# Altium schematic sheet RP2350+.SchDoc
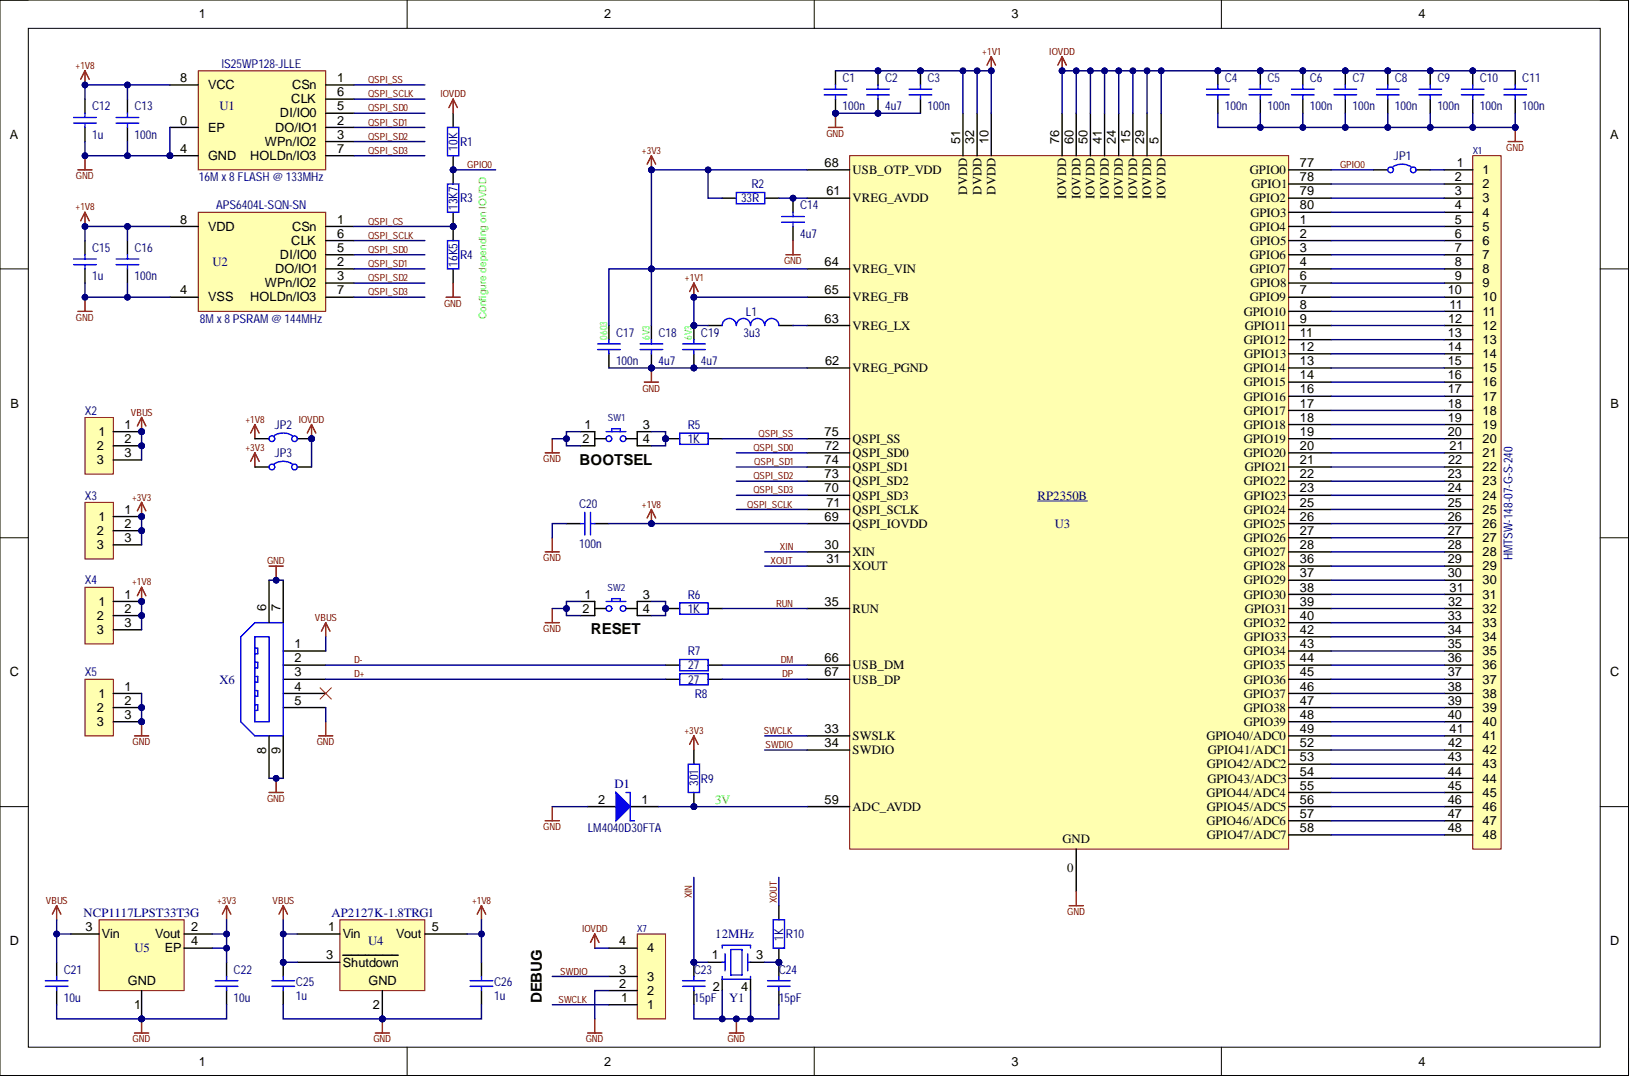
[diagram p0: RP2350+.SchDoc]

## Parts
C1  value 100n  [CAPC0402]  (hidden comment: C0402C104J8RACTU)
C2  value 4u7  [CAPC0402]  (hidden comment: CL05A475KP5NRNC)
C3  value 100n  [CAPC0402]  (hidden comment: C0402C104J8RACTU)
C4  value 100n  [CAPC0402]  (hidden comment: C0402C104J8RACTU)
C5  value 100n  [CAPC0402]  (hidden comment: C0402C104J8RACTU)
C6  value 100n  [CAPC0402]  (hidden comment: C0402C104J8RACTU)
C7  value 100n  [CAPC0402]  (hidden comment: C0402C104J8RACTU)
C8  value 100n  [CAPC0402]  (hidden comment: C0402C104J8RACTU)
C9  value 100n  [CAPC0402]  (hidden comment: C0402C104J8RACTU)
C10  value 100n  [CAPC0402]  (hidden comment: C0402C104J8RACTU)
C11  value 100n  [CAPC0402]  (hidden comment: C0402C104J8RACTU)
C12  value 1u  [CAPC0402]  (hidden comment: CL05A105KP5NNNC)
C13  value 100n  [CAPC0402]  (hidden comment: C0402C104J8RACTU)
C14  value 4u7  [CAPC0402]  (hidden comment: CL05A475KP5NRNC)
C15  value 1u  [CAPC0402]  (hidden comment: CL05A105KP5NNNC)
C16  value 100n  [CAPC0402]  (hidden comment: C0402C104J8RACTU)
C17  0603  value 100n  [CAPC0603]
C18  6V3  value 4u7  [CAPC0603]
C19  6V3  value 4u7  [CAPC0603]
C20  value 100n  [CAPC0402]  (hidden comment: C0402C104J8RACTU)
C21  value 10u  [CAPC0402]  (hidden comment: 6V3)
C22  value 10u  [CAPC0402]  (hidden comment: 6V3)
C23  value 15pF  [CAPC0402]  (hidden comment: GJM1555C1H3R0BB01D)
C24  value 15pF  [CAPC0402]  (hidden comment: GJM1555C1H3R0BB01D)
C25  value 1u  [CAPC0402]  (hidden comment: CL05A105KP5NNNC)
C26  value 1u  [CAPC0402]  (hidden comment: CL05A105KP5NNNC)
D1  LM4040D30FTA  [SOT23-3]
JP1  5110 Keystone  [JumperSMD_0603]  (value not drawn)  (no BOM)
JP2  5110 Keystone  [JumperSMD_0603]  (value not drawn)  (no BOM)
JP3  5110 Keystone  [JumperSMD_0603]  (value not drawn)  (no BOM)
L1  value 3u3  [IND_0806]  (hidden comment: IND_3u3_2X1.6mm_1.4A_20%)
R1  value 10K  [RESC0402]  (hidden comment: RC0402FR-0710KL)
R2  value 33R  [RESC0402]  (hidden comment: RC0402FR-0733RL)
R3  value 13K7  [RESC0402]  (hidden comment: 13K7_0402_63mW_1%_100ppm)
R4  value 16K5  [RESC0402]  (hidden comment: 16K5_0402_63mW_1%_100ppm)
R5  value 1K  [RESC0402]  (hidden comment: RC0402FR-071KL)
R6  value 1K  [RESC0402]  (hidden comment: RC0402FR-071KL)
R7  value 27  [RESC0402]  (hidden comment: CRCW040214K3FKED)
R8  value 27  [RESC0402]  (hidden comment: CRCW040214K3FKED)
R9  value 301  [RESC0402]  (hidden comment: 301R_0402_63mW_1%_100ppm)
R10  value 1K  [RESC0402]  (hidden comment: RC0402FR-071KL)
SW1  434133025816  [434133025816]  (value not drawn)
SW2  434133025816  [434133025816]  (value not drawn)
U1  IS25WP128-JLLE  value 16M x 8 FLASH @ 133MHz  [WSON8-6x8]
U2  APS6404L-SQN-SN  value 8M x 8 PSRAM @ 144MHz  [SOP-8L (150)]
U3  RP2350B  [QFN-80]
U4  AP2127K-1.8TRG1  [SOT23-5]
U5  NCP1117LPST33T3G  [SOT-232-4]
X1  HMTSW-148-07-G-S-240  [HDR_TH_1X48_M_00]
X2  825433-3  [HDR_TH_1X3_M_00]  (value not drawn)
X3  825433-3  [HDR_TH_1X3_M_00]  (value not drawn)
X4  825433-3  [HDR_TH_1X3_M_00]  (value not drawn)
X5  825433-3  [HDR_TH_1X3_M_00]  (value not drawn)
X6  1734327-1  [1734327-1]  (value not drawn)
X7  HMTSW-106-07-G-S-240  [HDR_TH_1X3+0+1_M_00]  (value not drawn)
Y1  value 12MHz  [ABM8]  (hidden comment: ABM8-272-T3)

## Nets
+1V1: C1.2 C2.2 C3.2 C19.2 L1.1 U3.10(DVDD) U3.32(DVDD) U3.51(DVDD) U3.65(VREG_FB)
+1V8: C12.1 C13.2 C15.1 C16.2 C20.2 C26.1 JP2.1 U1.8(VCC) U2.8(VDD) U3.69(QSPI_IOVDD) U4.5(Vout) X4.1 X4.2 X4.3
+3V3: C17.2 C18.2 C22.2 JP3.1 R2.1 R9.2 U3.64(VREG_VIN) U3.68(USB_OTP_VDD) U5.2(Vout) U5.4(EP) X3.1 X3.2 X3.3
GND: C1.1 C2.1 C3.1 C4.1 C5.1 C6.1 C7.1 C8.1 C9.1 C10.1 C11.1 C12.2 C13.1 C14.1 C15.2 C16.1 C17.1 C18.1 C19.1 C20.1 C21.1 C22.1 C23.1 C24.1 C25.2 C26.2 D1.2 R4.1 SW1.1 SW1.2 SW2.1 SW2.2 U1.0(EP) U1.4(GND) U2.4(VSS) U3.0 U3.62(VREG_PGND) U4.2 U5.1 X5.1 X5.2 X5.3 X6.5 X6.6 X6.7 X6.8 X6.9 X7.2 Y1.2 Y1.4
IOVDD: C4.2 C5.2 C6.2 C7.2 C8.2 C9.2 C10.2 C11.2 JP2.2 JP3.2 R1.1 U3.5(IOVDD) U3.15(IOVDD) U3.24(IOVDD) U3.29(IOVDD) U3.41(IOVDD) U3.50(IOVDD) U3.60(IOVDD) U3.76(IOVDD) X7.4
VBUS: C21.2 C25.1 U4.1(Vin) U4.3(Shutdown) U5.3(Vin) X2.1 X2.2 X2.3 X6.1
D+: R8.1 X6.3
D-: R7.1 X6.2
DM: R7.2 U3.66(USB_DM)
DP: R8.2 U3.67(USB_DP)
GPIO0: JP1.1 R1.2 R3.2 U3.77(GPIO0)
QSPI_CS: R3.1 R4.2 U2.1(CSn)
QSPI_SCLK: U1.6(CLK) U2.6(CLK) U3.71(QSPI_SCLK)
QSPI_SD0: U1.5(DI/IO0) U2.5(DI/IO0) U3.72(QSPI_SD0)
QSPI_SD1: U1.2(DO/IO1) U2.2(DO/IO1) U3.74(QSPI_SD1)
QSPI_SD2: U1.3(WPn/IO2) U2.3(WPn/IO2) U3.73(QSPI_SD2)
QSPI_SD3: U1.7(HOLDn/IO3) U2.7(HOLDn/IO3) U3.70(QSPI_SD3)
QSPI_SS: R5.2 U1.1(CSn) U3.75(QSPI_SS)
RUN: R6.2 U3.35(RUN)
SWCLK: U3.33(SWSLK) X7.1
SWDIO: U3.34(SWDIO) X7.3
XIN: C23.2 U3.30(XIN) Y1.1
XOUT: R10.2 U3.31(XOUT)
NetC14_2: C14.2 R2.2 U3.61(VREG_AVDD)
NetC24_2: C24.2 R10.1 Y1.3
NetD1_1: D1.1 R9.1 U3.59(ADC_AVDD)
NetJP1_2: JP1.2 X1.1
NetL1_2: L1.2 U3.63(VREG_LX)
NetR5_1: R5.1 SW1.3 SW1.4
NetR6_1: R6.1 SW2.3 SW2.4
NetU3_1: U3.1(GPIO4) X1.5
NetU3_2: U3.2(GPIO5) X1.6
NetU3_3: U3.3(GPIO6) X1.7
NetU3_4: U3.4(GPIO7) X1.8
NetU3_6: U3.6(GPIO8) X1.9
NetU3_7: U3.7(GPIO9) X1.10
NetU3_8: U3.8(GPIO10) X1.11
NetU3_9: U3.9(GPIO11) X1.12
NetU3_11: U3.11(GPIO12) X1.13
NetU3_12: U3.12(GPIO13) X1.14
NetU3_13: U3.13(GPIO14) X1.15
NetU3_14: U3.14(GPIO15) X1.16
NetU3_16: U3.16(GPIO16) X1.17
NetU3_17: U3.17(GPIO17) X1.18
NetU3_18: U3.18(GPIO18) X1.19
NetU3_19: U3.19(GPIO19) X1.20
NetU3_20: U3.20(GPIO20) X1.21
NetU3_21: U3.21(GPIO21) X1.22
NetU3_22: U3.22(GPIO22) X1.23
NetU3_23: U3.23(GPIO23) X1.24
NetU3_25: U3.25(GPIO24) X1.25
NetU3_26: U3.26(GPIO25) X1.26
NetU3_27: U3.27(GPIO26) X1.27
NetU3_28: U3.28(GPIO27) X1.28
NetU3_36: U3.36(GPIO28) X1.29
NetU3_37: U3.37(GPIO29) X1.30
NetU3_38: U3.38(GPIO30) X1.31
NetU3_39: U3.39(GPIO31) X1.32
NetU3_40: U3.40(GPIO32) X1.33
NetU3_42: U3.42(GPIO33) X1.34
NetU3_43: U3.43(GPIO34) X1.35
NetU3_44: U3.44(GPIO35) X1.36
NetU3_45: U3.45(GPIO36) X1.37
NetU3_46: U3.46(GPIO37) X1.38
NetU3_47: U3.47(GPIO38) X1.39
NetU3_48: U3.48(GPIO39) X1.40
NetU3_49: U3.49(GPIO40/ADC0) X1.41
NetU3_52: U3.52(GPIO41/ADC1) X1.42
NetU3_53: U3.53(GPIO42/ADC2) X1.43
NetU3_54: U3.54(GPIO43/ADC3) X1.44
NetU3_55: U3.55(GPIO44/ADC4) X1.45
NetU3_56: U3.56(GPIO45/ADC5) X1.46
NetU3_57: U3.57(GPIO46/ADC6) X1.47
NetU3_58: U3.58(GPIO47/ADC7) X1.48
NetU3_78: U3.78(GPIO1) X1.2
NetU3_79: U3.79(GPIO2) X1.3
NetU3_80: U3.80(GPIO3) X1.4

Not connected: X6 4

## Library data of the parts
C1 C3-C11 C13 C16 C20 = 100n_0402_10V_X7R_5%: Capacitor; Ceramic; 100n; 0402; 10V; X7R; 5%; -55°C ~ 125°C; Manufacturer: KEMET; Component Kind: Standard; ComponentLink1Description: Datasheet; ComponentLink1URL: https://content.kemet.com/datasheets/KEM_C1002_X7R_SMD.pdf; ComponentLink2Description: Supplier Info; ComponentLink2URL: https://www.digikey.com/en/products/detail/kemet/C0402C104J8RACTU/2196231?s=N4IgTCBcDaIMwE4EFoAcCAsZkDZkDkAREAXQF8g; Library Name: Capacitors.SCHLIB; Manufacturer Part Number: C0402C104J8RACTU; Supplier 1: DigiKey; Supplier Part Number 1: 399-8942-6-ND
C2 C14 = 4u7_0402_10V_X5R_10%: Capacitor; Ceramic; 4.7u; 0402; 10V; X5R; 10%; -55°C ~ 85°C; Manufacturer: Samsung Electro-Mechanics; Component Kind: Standard; ComponentLink1Description: Datasheet; ComponentLink1URL: https://media.digikey.com/pdf/Data%20Sheets/Samsung%20PDFs/CL05A475KP5NRNC_Spec.pdf; ComponentLink2Description: Supplier Info; ComponentLink2URL: https://www.digikey.com/en/products/detail/samsung-electro-mechanics/CL05A475KP5NRNC/3887138?s=N4IgTCBcDaIIxgOwDYC0cAsAOADKtAcgCIgC6AvkA; Library Name: Capacitors.SCHLIB; Manufacturer Part Number: CL05A475KP5NRNC; Supplier 1: DigiKey; Supplier Part Number 1: 1276-1480-6-ND
C12 C15 C25 C26 = 1u_0402_10V_X5R_10%: Capacitor; Ceramic; 1u; 0402; 10V; X5R; 10%; -55°C ~ 85°C; Manufacturer: Samsung Electro-Mechanics; Component Kind: Standard; ComponentLink1Description: Datasheet; ComponentLink1URL: https://www.samsungsem.com/resources/file/global/support/product_catalog/MLCC.pdf; ComponentLink2Description: Supplier Info; ComponentLink2URL: https://www.digikey.com/en/products/detail/samsung-electro-mechanics/CL05A105KP5NNNC/3886734?s=N4IgTCBcDaIIxgOwDYC0cAMLVoHIBEQBdAXyA; Library Name: Capacitors.SCHLIB; Manufacturer Part Number: CL05A105KP5NNNC; Supplier 1: DigiKey; Supplier Part Number 1: 1276-1076-6-ND
C17 = 100n_0603_50V_X7R_5%: Capacitor; Ceramic; 100n; 0603; 50V; X7R; 5%; -55°C ~ 125°C; Manufacturer: Yageo; Manufacturer Part Number: CC0603JRX7R9BB104; Component Kind: Standard; ComponentLink1Description: Datasheet; ComponentLink1URL: https://www.yageo.com/upload/media/product/productsearch/datasheet/mlcc/UPY-GPHC_X7R_6.3V-to-50V_18.pdf; ComponentLink2Description: Supplier Info; ComponentLink2URL: https://www.digikey.com/en/products/detail/yageo/CC0603JRX7R9BB104/5195188?s=N4IgTCBcDaIMwEYEFoEHY0E5kDZkDkAREAXQF8g; Library Name: Capacitors.SCHLIB; Supplier 1: DigiKey; Supplier Part Number 1: 311-1779-6-ND
C18 C19 = 4u7_0603_6V3_X7S_10%: Capacitor; Ceramic; 4.7u; 0603; 6.3V; X7S; 10%; -55°C ~ 125°C; Manufacturer: Murata Electronics; Manufacturer Part Number: GCJ188C70J475KE02D; Component Kind: Standard; ComponentLink1Description: Datasheet; ComponentLink1URL: https://search.murata.co.jp/Ceramy/image/img/A01X/G101/ENG/GCJ188C70J475KE02-01.pdf; ComponentLink2Description: Supplier Info; ComponentLink2URL: https://www.digikey.de/en/products/detail/murata-electronics/GCJ188C70J475KE02D/7363224; Library Name: symbols.SchLib; Supplier 1: DigiKey; Supplier Part Number 1: 490-16480-1-ND
C21 C22 = 10u_0402_6.3V_X5R_20%: Capacitor; Ceramic; 10u; 0402; 6.3V; X5R; 20%; -55°C ~ 85°C; Manufacturer: Murata Electronics; Manufacturer Part Number: GRM155R60J106ME05D; Component Kind: Standard; ComponentLink1Description: Datasheet; ComponentLink1URL: https://search.murata.co.jp/Ceramy/image/img/A01X/G101/ENG/GRM155R60J106ME05-01A.pdf; ComponentLink2Description: Supplier Info; ComponentLink2URL: https://www.digikey.de/en/products/detail/murata-electronics/GRM155R60J106ME05D/11500166; Library Name: symbols.SchLib; Supplier 1: DigiKey; Supplier Part Number 1: 490-GRM155R60J106ME05DCT-ND
C23 C24 = 15p_0402_25V_C0G/NP0_5%: Capacitor; Ceramic; 15p; 0402; 25V; C0G/NP0; +/-5%; -55°C ~ 125°C; Manufacturer: YAGEO; Manufacturer Part Number: CC0402JRNPO8BN150; Component Kind: Standard; ComponentLink1Description: Datasheet; ComponentLink1URL: https://www.yageo.com/upload/media/product/productsearch/datasheet/mlcc/UPY-GP_NP0_16V-to-50V_18.pdf; ComponentLink2Description: Supplier Info; ComponentLink2URL: https://www.digikey.de/en/products/detail/yageo/CC0402JRNPO8BN150/5883268; Library Name: symbols.SchLib; Supplier 1: DigiKey; Supplier Part Number 1: 311-3746-1-ND
D1 = LM4040D30FTA: Shunt Voltage Reference IC Fixed 3V ±1% 15 mA SOT-23-3; Manufacturer: Diodes Incorporated; Component Kind: Standard; ComponentLink1Description: Datasheet; ComponentLink1URL: https://www.diodes.com/assets/Datasheets/LM4040.pdf; ComponentLink2Description: Supplier Info; ComponentLink2URL: https://www.digikey.de/en/products/detail/diodes-incorporated/LM4040D30FTA/4249974; Library Name: symbols.SchLib; Manufacturer Part Number: LM4040D30FTA; Supplier 1: Digi-Key; Supplier Part Number 1: LM4040D30FTADICT-ND; Value: 3V
JP1-JP3 = JMP_0R_0603: Jumper; Chip; 0R; 0603; 500mW; Manufacturer Part Number: 5110; ComponentLink1Description: Datasheet; ComponentLink1URL: https://www.keyelco.com/userAssets/file/M65p54.pdf; ComponentLink2Description: Supplier Info; ComponentLink2URL: https://www.digikey.com/en/products/detail/keystone-electronics/5110/5118870?s=N4IgTCBcDaINIFMCeBnALgewHYIAQFYBGQgBhAF0BfIA; Library Name: Jumpers.SCHLIB; Manufacturer: Keystone; Supplier 1: DigiKey; Supplier Part Number 1: 36-5110CT-ND; Value: 0R
L1 = IND_3u3_2X1.6mm_1.4A_20%: 3.3 µH Shielded Inductor 1.4 A 225mOhm Max 0806; Manufacturer: Bourns Inc.; Manufacturer Part Number: SRP2010DPA-3R3M; Component Kind: Standard; ComponentLink1Description: Supplier Info; ComponentLink1URL: https://www.digikey.de/en/products/detail/bourns-inc/SRP2010DPA-3R3M/16185975?s=N4IgTCBcDaIMoCUAKYAMBGVARJBBAtAMwKECyIAugL5A; ComponentLink2Description: Datasheet; ComponentLink2URL: https://mm.digikey.com/Volume0/opasdata/d220001/medias/docus/5338/SRP2010DPA_Series_DS.pdf; Supplier 1: Digi-Key; Supplier Part Number 1: 118-SRP2010DPA-3R3MCT-ND; Library Name: Inductances.SCHLIB
R1 = 10K_0402_63mW_1%_100ppm: Resistor; Chip; 10k; 0402; 50V; 1%; 100ppm; 63mW; -55°C ~ 155°C; Manufacturer: Yageo; Component Kind: Standard; ComponentLink1Description: Datasheet; ComponentLink1URL: https://www.yageo.com/upload/media/product/productsearch/datasheet/rchip/PYu-RC_Group_51_RoHS_L_11.pdf; ComponentLink2Description: Supplier Info; ComponentLink2URL: https://www.digikey.com/en/products/detail/yageo/RC0402FR-0710KL/726523?s=N4IgTCBcDaIMwEYEFoEAYB0aDSAZASgCLb7IByhIAugL5A; Library Name: Resistors.SCHLIB; Manufacturer Part Number: RC0402FR-0710KL; Signal Integrity: Res; Simulation: RESISTOR; Supplier 1: DigiKey; Supplier Part Number 1: 311-10.0KLRDKR-ND
R2 = 33R_0402_63mW_1%_100ppm: Resistor; Chip; 33R; 0402; 50V; 1%; 100ppm; 63mW; -55°C ~ 155°C; Manufacturer: Yageo; Component Kind: Standard; ComponentLink1Description: Datasheet; ComponentLink1URL: https://www.yageo.com/upload/media/product/productsearch/datasheet/rchip/PYu-RC_Group_51_RoHS_L_11.pdf; ComponentLink2Description: Supplier Info; ComponentLink2URL: https://www.digikey.com/en/products/detail/yageo/RC0402FR-0733RL/726593?s=N4IgTCBcDaIMwEYEFo5wHQAYAyAlAIgNK7IBy%2BIAugL5A; Library Name: Resistors.SCHLIB; Manufacturer Part Number: RC0402FR-0733RL; Signal Integrity: Res; Simulation: RESISTOR; Supplier 1: DigiKey; Supplier Part Number 1: 311-33.0LRDKR-ND
R3 = 13K7_0402_63mW_1%_100ppm: Resistor; Chip; 13K7; 0402; 1%; 100ppm; 63mW; -55°C ~ 155°C; Manufacturer 1: YAGEO; Manufacturer Part Number 1: RC0402FR-0713K7L; Component Kind: Standard; ComponentLink1Description: Datasheet; ComponentLink1URL: https://www.yageo.com/upload/media/product/products/datasheet/rchip/PYu-RC_Group_51_RoHS_L_12.pdf; ComponentLink2Description: Supplier Info; ComponentLink2URL: https://www.digikey.de/en/products/detail/yageo/RC0402FR-0713K7L/2827638; Library Name: symbols.SchLib; Signal Integrity: Res; Simulation: RESISTOR; Supplier 1: DigiKey; Supplier Part Number 1: 311-13.7KLRCT-ND
R4 = 16K5_0402_63mW_1%_100ppm: Resistor; Chip; 16K5; 0402; 1%; 100ppm; 63mW; -55°C ~ 155°C; Manufacturer 1: YAGEO; Manufacturer Part Number 1: AC0402FR-0716K5L; Component Kind: Standard; ComponentLink1Description: Datasheet; ComponentLink1URL: https://www.yageo.com/upload/media/product/productsearch/datasheet/rchip/PYu-AC_51_RoHS_L_10.pdf; ComponentLink2Description: Supplier Info; ComponentLink2URL: https://www.digikey.de/en/products/detail/yageo/AC0402FR-0716K5L/5895098; Library Name: symbols.SchLib; Signal Integrity: Res; Simulation: RESISTOR; Supplier 1: DigiKey; Supplier Part Number 1: YAG5637CT-ND
R5 R6 R10 = 1K_0402_63mW_1%_100ppm: Resistor; Chip; 1k; 0402; 50V; 1%; 100ppm; 63mW; -55°C ~ 155°C; Manufacturer: Yageo; Component Kind: Standard; ComponentLink1Description: Datasheet; ComponentLink1URL: https://www.yageo.com/upload/media/product/productsearch/datasheet/rchip/PYu-RC_Group_51_RoHS_L_11.pdf; ComponentLink2Description: Supplier Info; ComponentLink2URL: https://www.digikey.com/en/products/detail/yageo/RC0402FR-071KL/726513?s=N4IgTCBcDaIMwEYEFoEDoAMGDSAZASgCLb7IByhIAugL5A; Library Name: Resistors.SCHLIB; Manufacturer Part Number: RC0402FR-071KL; Signal Integrity: Res; Simulation: RESISTOR; Supplier 1: DigiKey; Supplier Part Number 1: 311-1.00KLRDKR-ND
R7 R8 = 27R_0402_63mW_1%_100ppm: Resistor; Chip; 27Ohm; 0402; 1%; 100ppm; 63mW; -55°C ~ 155°C; Manufacturer: YAGEO; Manufacturer Part Number: RC0402FR-0727RL; Component Kind: Standard; ComponentLink1Description: Datasheet; ComponentLink1URL: https://www.yageo.com/upload/media/product/products/datasheet/rchip/PYu-RC_Group_51_RoHS_L_12.pdf; ComponentLink2Description: Supplier Info; ComponentLink2URL: https://www.digikey.de/en/products/detail/yageo/RC0402FR-0727RL/5280946; Library Name: symbols.SchLib; Signal Integrity: Res; Simulation: RESISTOR; Supplier 1: DigiKey; Supplier Part Number 1: YAG3086CT-ND
R9 = 301R_0402_63mW_1%_100ppm: Resistor; Chip; 301R; 0402; 1%; 100ppm; 63mW; -55°C ~ 155°C; Manufacturer: YAGEO; Manufacturer Part Number: RC0402FR-07301RL; Component Kind: Standard; ComponentLink1Description: Datasheet; ComponentLink1URL: https://www.yageo.com/upload/media/product/products/datasheet/rchip/PYu-RC_Group_51_RoHS_L_12.pdf; ComponentLink2Description: Supplier Info; ComponentLink2URL: https://www.digikey.de/en/products/detail/yageo/RC0402FR-07301RL/726591; Library Name: symbols.SchLib; Signal Integrity: Res; Simulation: RESISTOR; Supplier 1: DigiKey; Supplier Part Number 1: 311-301LRCT-ND
SW1 SW2 = 434133025816: SWITCH TACTILE SPST-NO 0.05A 16V; Manufacturer: Würth Elektronik; Component Kind: Standard; ComponentLink1Description: Datasheet; ComponentLink1URL: https://www.we-online.com/components/products/datasheet/434133025816.pdf; ComponentLink2Description: Supplier Info; ComponentLink2URL: https://www.digikey.de/en/products/detail/w%C3%BCrth-elektronik/434133025816/9950810?s=N4IgTCBcDaICwGY4EYEIAxgKwA5kDYQBdAXyA; Library Name: symbols.SchLib; Manufacturer Part Number: 434133025816; Supplier 1: Digi-Key; Supplier Part Number 1: 732-13663-1-ND
U1 = IS25WP128-JLLE: IC FLASH 128MBIT SPI/QUAD 8WSON; Manufacturer: ISSI, Integrated Silicon Solution Inc; Component Kind: Standard; ComponentLink1Description: Datasheet; ComponentLink1URL: https://www.issi.com/WW/pdf/25WP128.pdf; ComponentLink2Description: Supplier Info; ComponentLink2URL: https://www.digikey.de/en/products/detail/issi-integrated-silicon-solution-inc/IS25WP128-JLLE/7567476; Library Name: symbols.SchLib; Manufacturer Part Number: IS25WP128-JLLE; Supplier 1: Digi-Key; Supplier Part Number 1: 706-1625-ND
U2 = APS6404L-SQN-SN: IC PSRAM 64MBIT QSPI SOP-8L; Manufacturer: AP Memory; Component Kind: Standard; ComponentLink1Description: Datasheet; ComponentLink1URL: https://www.mouser.de/datasheet/2/1127/APM_PSRAM_QSPI_APS6404L_SQN_v3_6_PKG-1954836.pdf; ComponentLink2Description: Supplier Info; ComponentLink2URL: https://www.mouser.de/ProductDetail/AP-Memory/APS6404L-SQN-SN?qs=sGAEpiMZZMutXGli8Ay4kD40unR62EiRpDwP77v2d3Y%3D; Library Name: symbols.SchLib; Manufacturer Part Number: APS6404L-SQN-SN; Supplier 1: Mouser; Supplier Part Number 1: 878-APS6404L-SQN-SN
U3 = RP2350B: IC MCU 32BIT EXT MEM 80QFN; Component Kind: Standard; ComponentLink1Description: Datasheet; ComponentLink1URL: https://datasheets.raspberrypi.com/rp2350/rp2350-datasheet.pdf; ComponentLink2Description: Supplier Info; Library Name: symbols.SchLib
U4 = AP2127K-1.8TRG1: IC REG LINEAR 1.8V 300MA SOT23-5; Manufacturer: Diodes Incorporated; Component Kind: Standard; ComponentLink1Description: Datasheet; ComponentLink1URL: https://www.diodes.com/assets/Datasheets/AP2127.pdf; ComponentLink2Description: Supplier Info; ComponentLink2URL: https://www.digikey.de/en/products/detail/diodes-incorporated/AP2127K-1-8TRG1/4470784; Library Name: symbols.SchLib; Manufacturer Part Number: AP2127K-1.8TRG1; Supplier 1: Digi-Key; Supplier Part Number 1: AP2127K-1.8TRG1DICT-ND
U5 = NCP1117LPST33T3G: IC REG LINEAR 3.3V 1A SOT223; Manufacturer: onsemi; Component Kind: Standard; ComponentLink1Description: Datasheet; ComponentLink1URL: https://www.onsemi.com/pdf/datasheet/ncp1117lp-d.pdf; ComponentLink2Description: Supplier Info; ComponentLink2URL: https://www.digikey.de/en/products/detail/onsemi/NCP1117LPST33T3G/2194024; Library Name: symbols.SchLib; Manufacturer Part Number: NCP1117LPST33T3G; Supplier 1: DigiKey; Supplier Part Number 1: NCP1117LPST33T3GOSCT-ND
X1 = HDR_TH_1X48_M_00: Header; 1x48 pins; Male; Straight; Pitch 2.54mm; Samtec HMTSW Series; Manufacturer: Samtec Inc.; Component Kind: Standard; ComponentLink1Description: Supplier Info; ComponentLink2Description: Datasheet; ComponentLink2URL: https://www.samtec.com/products/hmtsw-148-07-g-s-240; Library Name: symbols.SchLib; Manufacturer Part Number: HMTSW-148-07-G-S-240
X2-X5 = HDR_TH_1X3_M_00: Header; 1x3 pins; Male; Straight; Pitch 2.54mm; Manufacturer: TE Connectivity; Manufacturer Part Number: 825433-2; Component Kind: Standard; ComponentLink1Description: Supplier Info; ComponentLink1URL: https://www.digikey.de/product-detail/en/te-connectivity-amp-connectors/825433-3/A106465-ND/2054408; ComponentLink2Description: Datasheet; ComponentLink2URL: https://www.te.com/commerce/DocumentDelivery/DDEController?Action=srchrtrv&DocNm=825433&DocType=Customer+Drawing&DocLang=English; Supplier 1: Digi-Key; Supplier Part Number 1: A106465-ND; Value: HDR_TH_1X3_M_00; Library Name: Connections.SchLib
X6 = 1734327-1: USB - mini A USB 2.0 Receptacle Connector 5 Position Surface Mount, Right Angle; Manufacturer: TE Connectivity AMP Connectors; Component Kind: Standard; ComponentLink1Description: Datasheet; ComponentLink1URL: https://www.mouser.de/datasheet/2/418/7/ENG_CD_1734327_D1-2016926.pdf; ComponentLink2Description: Supplier Info; ComponentLink2URL: https://www.digikey.de/en/products/detail/te-connectivity-amp-connectors/1734327-1/1891548; Library Name: symbols.SchLib; Manufacturer Part Number: 1734327-1; Supplier 1: Digi-Key; Supplier Part Number 1: A123107-ND; Value: 1734327-1
X7 = HDR_TH_1X3+1_M_00: Header; 1x5 pins (with key); Male; Straight; Pitch 2.54mm; Samtec HMTSW Series; Manufacturer: Samtec Inc.; Manufacturer Part Number: HMTSW-105-07-G-S-240; Component Kind: Standard; ComponentLink1Description: Supplier Info; ComponentLink1URL: https://www.digikey.de/en/products/detail/samtec-inc/HMTSW-105-07-G-S-240/8451402; ComponentLink2Description: Datasheet; ComponentLink2URL: http://suddendocs.samtec.com/catalog_english/mtsw.pdf; Library Name: symbols.SchLib; Supplier 1: DigiKey; Supplier Part Number 1: 612-HMTSW-105-07-G-S-240-ND; Value: HDR_TH_1X3+1_M_00
Y1 = ABM8-272-T3: Christal; SMD; 12 MHz ±30ppm; 10pF/50Ohm; Manufacturer: Abracon LLC; Component Kind: Standard; ComponentLink1Description: Datasheet; ComponentLink1URL: https://mm.digikey.com/Volume0/opasdata/d220001/medias/docus/6128/ABM8-272-T3.pdf; ComponentLink2Description: Supplier Info; ComponentLink2URL: https://www.digikey.de/en/products/detail/abracon-llc/ABM8-272-T3/22472366; Library Name: symbols.SchLib; Manufacturer Part Number: ABM8-272-T3; Supplier 1: Digi-Key; Supplier Part Number 1: 535-ABM8-272-T3CT-ND
